# Altium schematic sheet ADC.SchDoc(ADC)
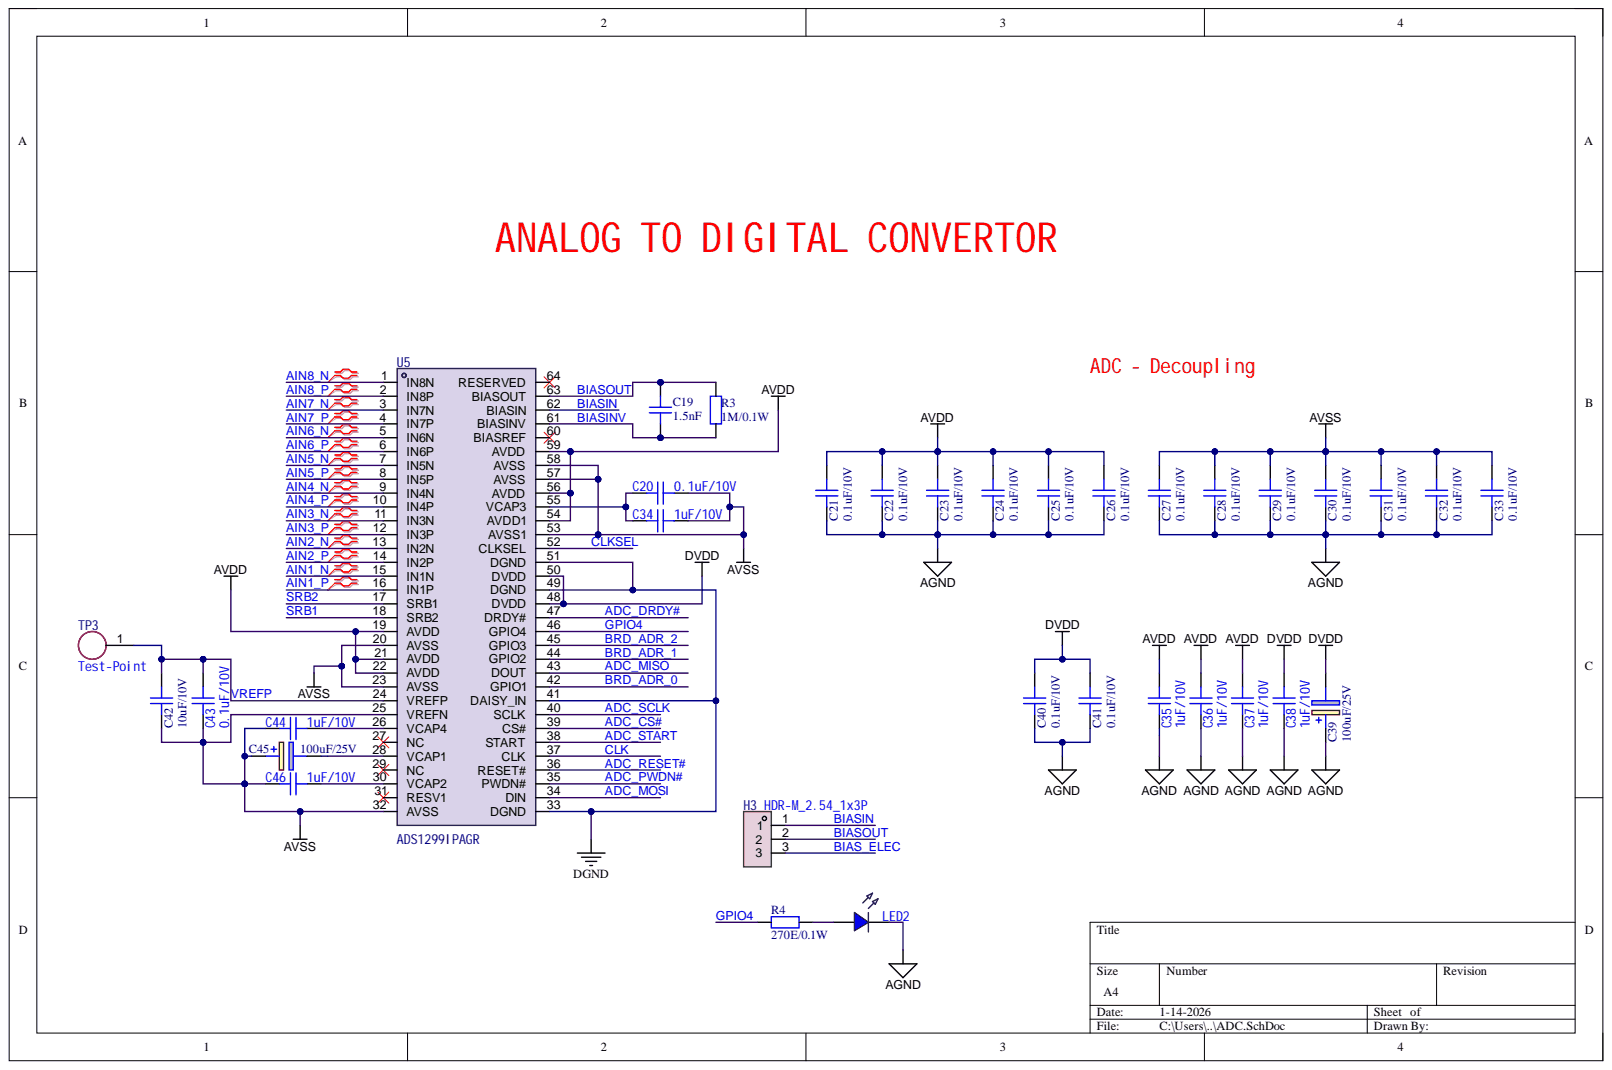
[diagram p0: ADC.SchDoc]

## Parts
C19  value 1.5nF  [c0603]  (hidden comment: CL10B152KB8NNNC)
C20  0.1uF  value 0.1uF/10V  [C0603]
C21  value 0.1uF/10V  [C0402]  (hidden comment: C0402C104K8RACTU)
C22  value 0.1uF/10V  [C0402]  (hidden comment: C0402C104K8RACTU)
C23  value 0.1uF/10V  [C0402]  (hidden comment: C0402C104K8RACTU)
C24  value 0.1uF/10V  [C0402]  (hidden comment: C0402C104K8RACTU)
C25  value 0.1uF/10V  [C0402]  (hidden comment: C0402C104K8RACTU)
C26  value 0.1uF/10V  [C0402]  (hidden comment: C0402C104K8RACTU)
C27  value 0.1uF/10V  [C0402]  (hidden comment: C0402C104K8RACTU)
C28  value 0.1uF/10V  [C0402]  (hidden comment: C0402C104K8RACTU)
C29  value 0.1uF/10V  [C0402]  (hidden comment: C0402C104K8RACTU)
C30  value 0.1uF/10V  [C0402]  (hidden comment: C0402C104K8RACTU)
C31  value 0.1uF/10V  [C0402]  (hidden comment: C0402C104K8RACTU)
C32  value 0.1uF/10V  [C0402]  (hidden comment: C0402C104K8RACTU)
C33  value 0.1uF/10V  [C0402]  (hidden comment: C0402C104K8RACTU)
C34  value 1uF/10V  [C0603]  (hidden comment: C0603C105K8RACAUTO7411)
C35  value 1uF/10V  [C0603]  (hidden comment: C0603C105K8RACAUTO7411)
C36  value 1uF/10V  [C0603]  (hidden comment: C0603C105K8RACAUTO7411)
C37  value 1uF/10V  [C0603]  (hidden comment: C0603C105K8RACAUTO7411)
C38  value 1uF/10V  [C0603]  (hidden comment: C0603C105K8RACAUTO7411)
C39  value 100uF/25V  [593D107X9010D2TE3]  (hidden comment: T495X107K025ATE150)
C40  value 0.1uF/10V  [C0402]  (hidden comment: C0402C104K8RACTU)
C41  value 0.1uF/10V  [C0402]  (hidden comment: C0402C104K8RACTU)
C42  value 10uF/10V  [c0603]  (hidden comment: GRM188Z71A106KA73D)
C43  0.1uF  value 0.1uF/10V  [C0603]
C44  value 1uF/10V  [C0603]  (hidden comment: C0603C105K8RACAUTO7411)
C45  value 100uF/25V  [593D107X9010D2TE3]  (hidden comment: T495X107K025ATE150)
C46  value 1uF/10V  [C0603]  (hidden comment: C0603C105K8RACAUTO7411)
H3  HDR-M_2.54_1x3P  [HDR-TH_3P-P2.54-V-M]
LED2  150080BS75000  [LED0805-RD_GREEN]  (value not drawn)
R3  value 1M/0.1W  [r0603]  (hidden comment: RCG06031M00FKEA)
R4  value 270E/0.1W  [R0603]  (hidden comment: CRG0603F270R)
TP3  Test-Point  [Test-Point-0.5mm]
U5  ADS1299IPAGR  [TQFP-64_L10.0-W10.0-P0.50-LS12.0-TL]

## Nets
AGND: C21.2 C22.2 C23.2 C24.2 C25.2 C26.2 C27.2 C28.2 C29.2 C30.2 C31.2 C32.2 C33.2 C35.2 C36.2 C37.2 C38.2 C39.1 C40.2 C41.2 LED2.1
AVDD: C21.1 C22.1 C23.1 C24.1 C25.1 C26.1 C35.1 C36.1 C37.1 U5.19(AVDD) U5.21(AVDD) U5.22(AVDD) U5.54(AVDD1) U5.56(AVDD) U5.59(AVDD)
AVSS: C20.1 C27.1 C28.1 C29.1 C30.1 C31.1 C32.1 C33.1 C34.1 C42.2 C43.2 C44.2 C45.1 C46.2 U5.20(AVSS) U5.23(AVSS) U5.25(VREFN) U5.32(AVSS) U5.53(AVSS1) U5.57(AVSS) U5.58(AVSS)
DGND: U5.33(DGND) U5.41(DAISY_IN) U5.49(DGND) U5.51(DGND)
DVDD: C38.1 C39.2 C40.1 C41.1 U5.48(DVDD) U5.50(DVDD)
ADC_CS#: U5.39(CS#)
ADC_DRDY#: U5.47(DRDY#)
ADC_MISO: U5.43(DOUT)
ADC_MOSI: U5.34(DIN)
ADC_PWDN#: U5.35(PWDN#)
ADC_RESET#: U5.36(RESET#)
ADC_SCLK: U5.40(SCLK)
ADC_START: U5.38(START)
AIN1_N: U5.15(IN1N)
AIN1_P: U5.16(IN1P)
AIN2_N: U5.13(IN2N)
AIN2_P: U5.14(IN2P)
AIN3_N: U5.11(IN3N)
AIN3_P: U5.12(IN3P)
AIN4_N: U5.9(IN4N)
AIN4_P: U5.10(IN4P)
AIN5_N: U5.7(IN5N)
AIN5_P: U5.8(IN5P)
AIN6_N: U5.5(IN6N)
AIN6_P: U5.6(IN6P)
AIN7_N: U5.3(IN7N)
AIN7_P: U5.4(IN7P)
AIN8_N: U5.1(IN8N)
AIN8_P: U5.2(IN8P)
BIAS_ELEC: H3.3
BIASIN: H3.1 U5.62(BIASIN)
BIASINV: C19.2 R3.1 U5.61(BIASINV)
BIASOUT: C19.1 H3.2 R3.2 U5.63(BIASOUT)
BRD_ADR_0: U5.42(GPIO1)
BRD_ADR_1: U5.44(GPIO2)
BRD_ADR_2: U5.45(GPIO3)
CLK: U5.37(CLK)
CLKSEL: U5.52(CLKSEL)
GPIO4: R4.1 U5.46(GPIO4)
SRB1: U5.18(SRB2)
SRB2: U5.17(SRB1)
VREFP: C42.1 C43.1 TP3.1 U5.24(VREFP)
NetC20_2: C20.2 C34.2 U5.55(VCAP3)
NetC44_1: C44.1 U5.26(VCAP4)
NetC45_2: C45.2 U5.28(VCAP1)
NetC46_1: C46.1 U5.30(VCAP2)
NetLED2_2: LED2.2 R4.2

Not connected: U5 27(NC) 29(NC) 31(RESV1) 60(BIASREF) 64(RESERVED)

## Library data of the parts
C19 = CL10B152KB8NNNC: Multilayer Ceramic Capacitors MLCC - SMD/SMT 1.5nF+/-10% 50V X7R 0603; Component Kind: Standard; ComponentLink1Description: Product link; ComponentLink1URL: https://www.mouser.in/ProductDetail/Samsung-Electro-Mechanics/CL10B152KB8NNNC?qs=349EhDEZ59qqqFKK4uDB8w%3D%3D; ComponentLink2Description: Datasheet link; Library Name: US00001.SCHLIB
C20 C43 = CC0603KRX7R6BB104: CAP CER 0.1UF 10V X7R 0603; Add into BOM: yes; Device: CAP_0603; Component Kind: Standard; ComponentLink1Description: Product link; ComponentLink1URL: https://www.digikey.in/en/products/detail/yageo/CC0603KRX7R6BB104/5883741; Convert to PCB: yes; Library Name: US00001.SCHLIB; Supplier Footprint: 0603; Symbol: CAP
C21-C33 C40 C41 = C0402C104K8RACTU: Multilayer Ceramic Capacitors MLCC - SMD/SMT 10V 0.1uF X7R 0402 10%; Component Kind: Standard; ComponentLink1Description: Product Link; ComponentLink1URL: https://www.mouser.in/ProductDetail/KEMET/C0402C104K8RACTU?qs=DBJr%252BvBUjU6yDZLyeyLtcA%3D%3D; Library Name: US00001.SCHLIB
C34-C38 C44 C46 = C0603C105K8RACAUTO7411: Multilayer Ceramic Capacitors MLCC - SMD/SMT 10 Vol 1 uF 0603 X7R 10 % AECQ200; Add into BOM: yes; Device: CAP_0603; Symbol: CAP; Component Kind: Standard; ComponentLink1Description: Product Link; ComponentLink1URL: https://www.mouser.in/ProductDetail/KEMET/C0603C105K8RACAUTO7411?qs=55YtniHzbhDsN9XQhinV0w%3D%3D; Convert to PCB: yes; Library Name: US00001.SCHLIB; Supplier Footprint: 0603
C39 C45 = T495X107K025ATE150: Tantalum Capacitors - Solid SMD 25V 100uF 2917 10%; Component Kind: Standard; ComponentLink1Description: Product Link; ComponentLink1URL: https://www.mouser.in/ProductDetail/KEMET/T495X107K025ATE150?qs=KP8Io%2FXyE5RXCJmm67juAA%3D%3D; ComponentLink2Description: Datasheet LInk; ComponentLink2URL: https://www.mouser.in/datasheet/3/72/1/KEM_T2009_T495.pdf; Library Name: US00001.SCHLIB
C42 = GRM188Z71A106KA73D: Multilayer Ceramic Capacitors MLCC - SMD/SMT 10 uF 10 VDC 10% 0603 X7R; Value: 10uF/10V; Component Kind: Standard; ComponentLink1Description: Product link; ComponentLink1URL: https://www.mouser.in/ProductDetail/Murata-Electronics/GRM188Z71A106KA73D?qs=d0WKAl%252BL4KaRM1L%252BaIs%2FqQ%3D%3D; Library Name: US00001.SCHLIB
H3 = HDR-M_2.54_1x3P: Add into BOM: yes; Symbol: PZ254V-11-03P_C2937625; Component Kind: Standard; Convert to PCB: yes; Device: HDR-M_2.54_1x3P; Library Name: US00001.SCHLIB; Supplier Footprint: Through
LED2 = 150080BS75000: LED BLUE CLEAR 0805 SMD; Add into BOM: yes; Device: LED_0805-B; Symbol: LED_B; Component Kind: Standard; ComponentLink1Description: Product link; ComponentLink1URL: https://www.digikey.in/en/products/detail/w%C3%BCrth-elektronik/150080BS75000/4489912; Convert to PCB: yes; Library Name: US00001.SCHLIB; Supplier Footprint: 0805
R3 = RCG06031M00FKEA: RES 1M OHM 1% 1/10W 0603; Component Kind: Standard; ComponentLink1Description: Product Link; ComponentLink1URL: https://www.digikey.in/en/products/detail/vishay-dale/RCG06031M00FKEA/4172398; Library Name: US00001.SCHLIB
R4 = CRG0603F270R: RES SMD 270 OHM 1% 1/10W 0603; Component Kind: Standard; ComponentLink1Description: Product link; ComponentLink1URL: https://www.digikey.in/en/products/detail/te-connectivity-passive-product/CRG0603F270R/2380754; Library Name: US00001.SCHLIB
TP3 = Test-Point: Add into BOM: yes; Component Kind: Standard; Convert to PCB: yes; Device: Test-Point; Library Name: US00001.SCHLIB; Symbol: Test-Point
U5 = ADS1299IPAGR: 8 Channel AFE 24 Bit 42 mW 64-TQFP (10x10); Manufacturer: TI(德州仪器); Add into BOM: yes; Resolution(Bits): 24; S/N Ratio: 121dB; Voltage - Supply: 4.75V~5.25V; Component Kind: Standard; ComponentLink1Description: Product Link; ComponentLink1URL: https://www.digikey.in/en/products/detail/texas-instruments/ADS1299IPAGR/3536907?s=N4IgTCBcDaIIIBEDKBGMBOdBJACnA4gEogC6AvkA; ComponentLink2Description: Datasheet Link; ComponentLink2URL: https://www.ti.com/lit/ds/symlink/ads1299.pdf?HQS=dis-dk-null-digikeymode-dsf-pf-null-wwe&ts=1767588097950&ref_url=https%253A%252F%252Fwww.ti.com%252Fgeneral%252Fdocs%252Fsuppproductinfo.tsp%253FdistId%253D10%2526gotoUrl%253Dhttps%253A%252F%252Fwww.ti.com%252Flit%252Fgpn%252Fads1299; Convert to PCB: yes; Datasheet: https://www.ti.com/cn/lit/gpn/ads1299; Device: ADS1299IPAGR; JLCPCB Part Class: Extended Part; LCSC Part Name: ADS1299-x 低噪声、4/6/8通道、24bit 、同时采样模数转换器，用于EEG和生物电bit 测量; Library Name: US00001.SCHLIB; Manufacturer Part: ADS1299IPAGR; Operating Temperature: -40℃~+85℃; Supplier: LCSC; Supplier Footprint: TQFP-64(10x10); Supplier Part: C476817; Symbol: ADS1299IPAGR
